# Altium schematic sheet Hovedkort.SchDoc
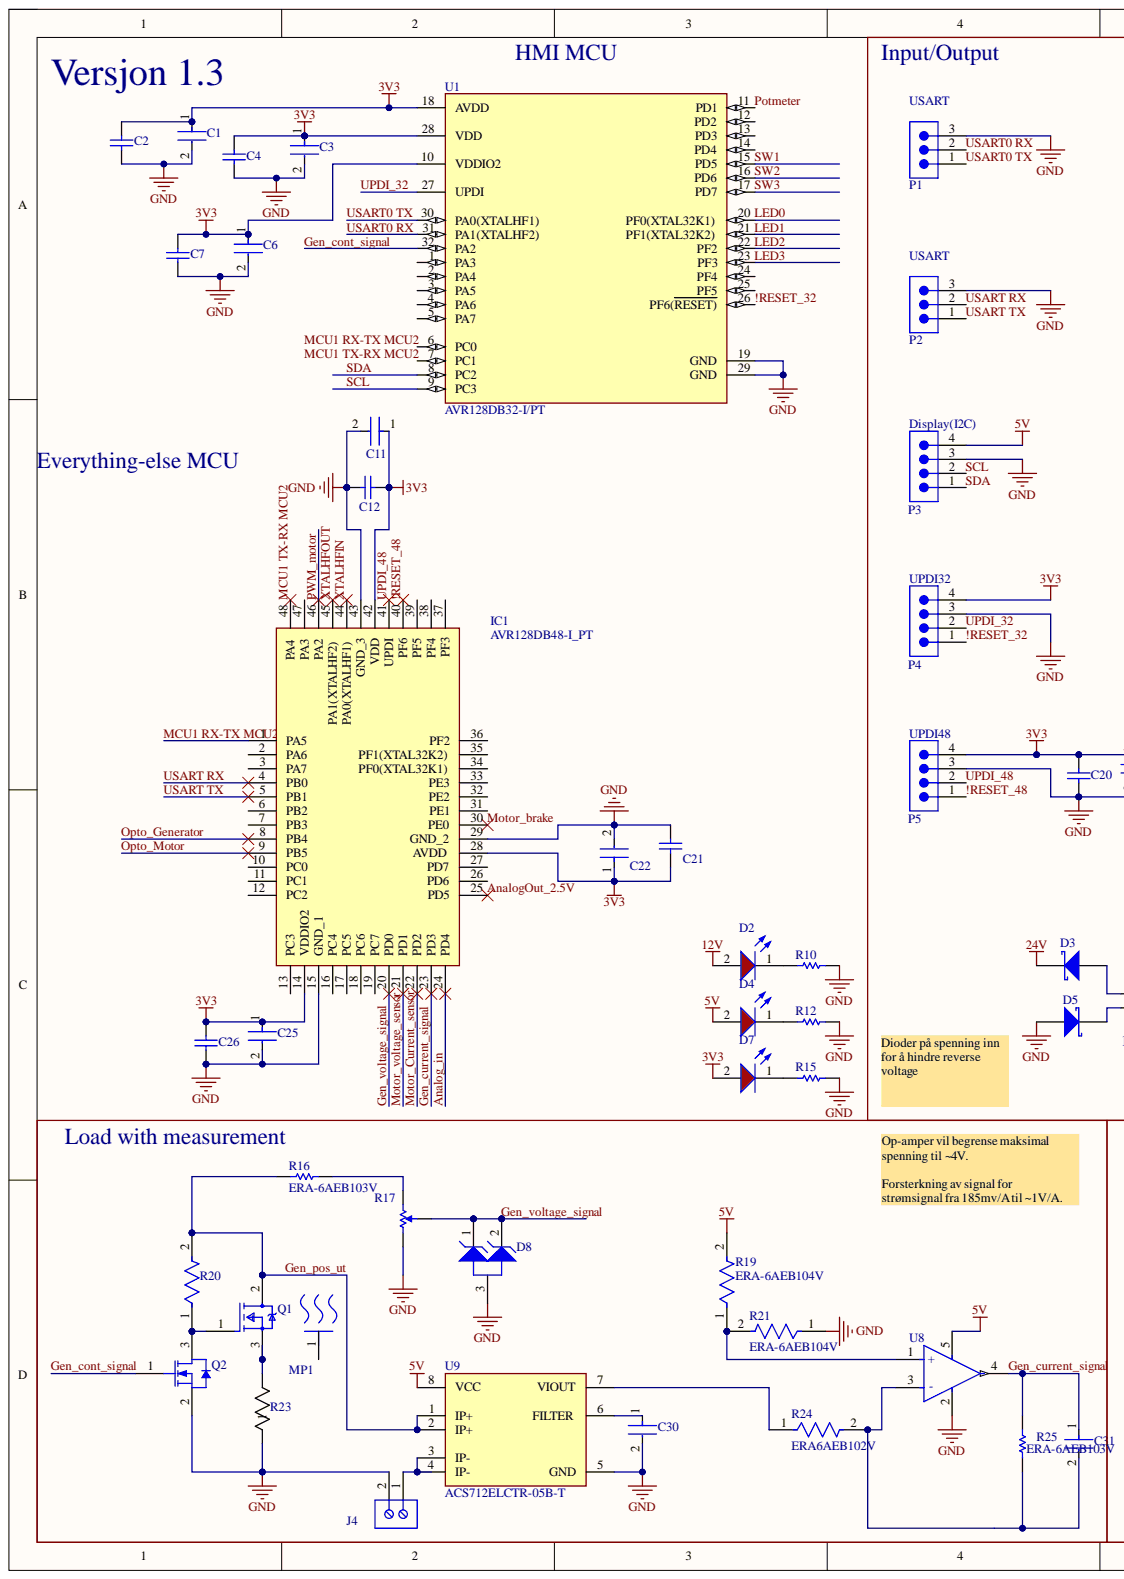
[diagram p0: Hovedkort.SchDoc, left]
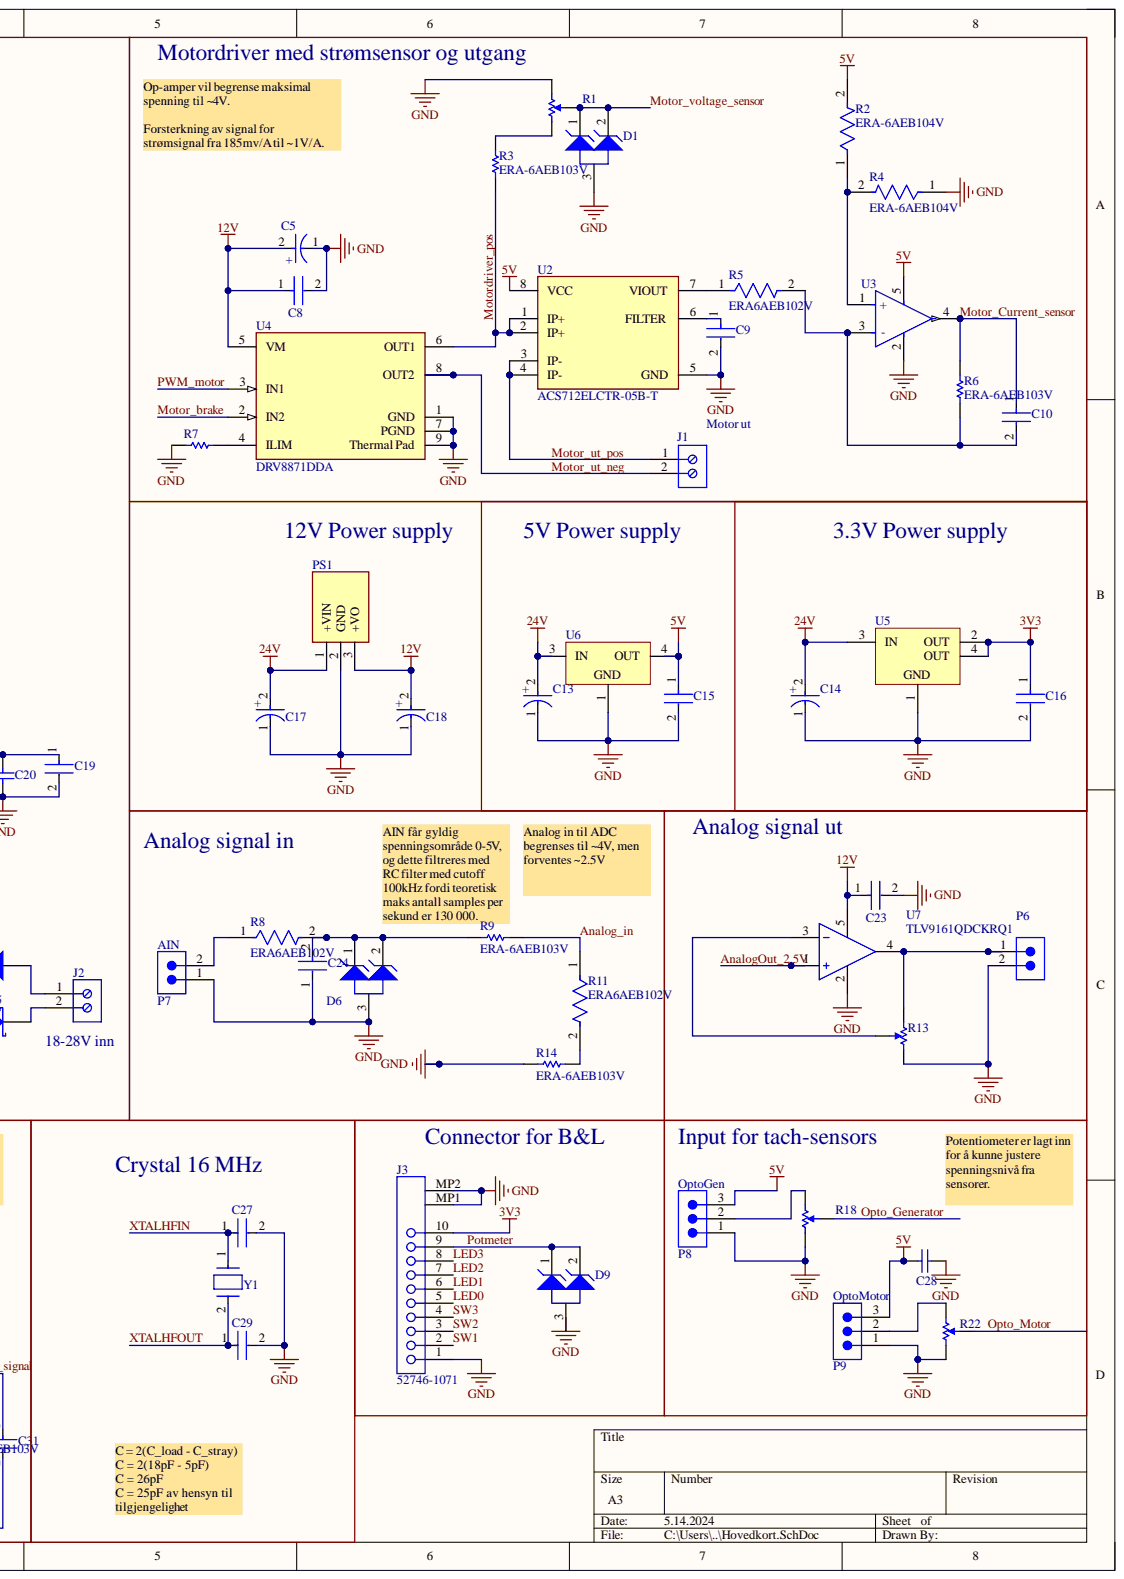
[diagram p1: Hovedkort.SchDoc, right]

## Parts
C1  08055C104KAT2A  [FP-0805-L_2_01_0_2-W_1_25-IPC_C]  (value not drawn)
C2  C0805C103K5RACTU  [CAPC2013X88X50LL20T25]  (value not drawn)
C3  08055C104KAT2A  [FP-0805-L_2_01_0_2-W_1_25-IPC_C]  (value not drawn)
C4  C0805C103K5RACTU  [CAPC2013X88X50LL20T25]  (value not drawn)
C5  EEEFK1V220R  [FP-FKC-MFG]  (value not drawn)
C6  08055C104KAT2A  [FP-0805-L_2_01_0_2-W_1_25-IPC_C]  (value not drawn)
C7  C0805C103K5RACTU  [CAPC2013X88X50LL20T25]  (value not drawn)
C8  08055C104KAT2A  [FP-0805-L_2_01_0_2-W_1_25-IPC_C]  (value not drawn)
C9  08055C104KAT2A  [FP-0805-L_2_01_0_2-W_1_25-IPC_C]  (value not drawn)
C10  C0805C102J1GACTU  [FP-C0805C-DN-MFG]  (value not drawn)
C11  08055C104KAT2A  [FP-0805-L_2_01_0_2-W_1_25-IPC_C]  (value not drawn)
C12  C0805C103K5RACTU  [CAPC2013X88X50LL20T25]  (value not drawn)
C13  EEE-FK1H100UR  [FP-FKC-MFG]  (value not drawn)
C14  EEE-FK1H100UR  [FP-FKC-MFG]  (value not drawn)
C15  C0805C106K8PACTU  [FP-C0805C-DG-MFG]  (value not drawn)
C16  C0805C106K8PACTU  [FP-C0805C-DG-MFG]  (value not drawn)
C17  EEEFK1V220R  [FP-FKC-MFG]  (value not drawn)
C18  EEEFK1V220R  [FP-FKC-MFG]  (value not drawn)
C19  08055C104KAT2A  [FP-0805-L_2_01_0_2-W_1_25-IPC_C]  (value not drawn)
C20  C0805C103K5RACTU  [CAPC2013X88X50LL20T25]  (value not drawn)
C21  C0805C103K5RACTU  [CAPC2013X88X50LL20T25]  (value not drawn)
C22  08055C104KAT2A  [FP-0805-L_2_01_0_2-W_1_25-IPC_C]  (value not drawn)
C23  08055C104KAT2A  [FP-0805-L_2_01_0_2-W_1_25-IPC_C]  (value not drawn)
C24  GRM2165C2A162JA01D  [FP-GRM216-0_1-IPC_A]  (value not drawn)
C25  08055C104KAT2A  [FP-0805-L_2_01_0_2-W_1_25-IPC_C]  (value not drawn)
C26  C0805C103K5RACTU  [CAPC2013X88X50LL20T25]  (value not drawn)
C27  C0805C103J3GACTU  [FP-C0805C-DN-MFG]  (value not drawn)
C28  C0805C103K5RACTU  [CAPC2013X88X50LL20T25]  (value not drawn)
C29  C0805C103J3GACTU  [FP-C0805C-DN-MFG]  (value not drawn)
C30  08055C104KAT2A  [FP-0805-L_2_01_0_2-W_1_25-IPC_C]  (value not drawn)
C31  C0805C102J1GACTU  [FP-C0805C-DN-MFG]  (value not drawn)
D1  MMBZ6V2ALT1G  [ONSC-SOT-23-3-318-08_V]  (value not drawn)
D2  150080SS75000  [WL-SMCW_0805_150080xx75000]  (value not drawn)
D3  STPS2H100A  [STM-SMA-2_V]  (value not drawn)
D4  Max Reverse Voltage (DC): 5V  [WL-SMCW_0805_150080xx75000]  (hidden comment: 150080SS75000)
D5  STPS2H100A  [STM-SMA-2_V]  (value not drawn)
D6  ESD7L5.0DT5G  [ONSC-SOT-723-3-631AA-01_V]  (value not drawn)
D7  150080SS75000  [WL-SMCW_0805_150080xx75000]  (value not drawn)
D8  MMBZ6V2ALT1G  [ONSC-SOT-23-3-318-08_V]  (value not drawn)
D9  SZMMBZ27VAWT1G  [ONSC-SC-70-3-419-04_V]  (value not drawn)
IC1  AVR128DB48-I_PT  [QFP50P900X900X120-48N]
J1  691103110002  [691103110002]  (value not drawn)
J2  691103110002  [691103110002]  (value not drawn)
J3  52746-1071  [MOLX-52746-1071]
J4  691103110002  [691103110002]  (value not drawn)
MP1  FA-T220-25E  [FP-FA-T220-25E-MFG]  (value not drawn)
P1  61300311121  [61300311121]  (value not drawn)
P2  61300311121  [61300311121]  (value not drawn)
P3  61300411121  [61300411121]  (value not drawn)
P4  61300411121  [61300411121]  (value not drawn)
P5  61300411121  [61300411121]  (value not drawn)
P6  61300211121  [61300211121]  (value not drawn)
P7  61300211121  [61300211121]  (value not drawn)
P8  61300311121  [61300311121]  (value not drawn)
P9  61300311121  [61300311121]  (value not drawn)
PS1  P7812-2000R-S  [P78032000RS]  (value not drawn)
Q1  IRFZ44VPBF  [FP-PG-TO220-3-MFG]  (value not drawn)
Q2  BSH103,215  [NXP-SOT23_N]  (value not drawn)
R1  TC33X-2-103E  [BOUR-TC33X-2_V]  (value not drawn)
R2  ERA-6AEB104V  [FP-ERA6A-IPC_B]
R3  ERA-6AEB103V  [RESC2013X60X40LL20T25_A]
R4  ERA-6AEB104V  [FP-ERA6A-IPC_B]
R5  ERA6AEB102V  [FP-ERA6A-IPC_C]
R6  ERA-6AEB103V  [RESC2013X60X40LL20T25_A]
R7  ERJ-6GEYJ303V  [RESC2013X70X40NL20T20]  (value not drawn)
R8  ERA6AEB102V  [FP-ERA6A-IPC_C]
R9  ERA-6AEB103V  [RESC2013X60X40LL20T25_A]
R10  ERJ-6GEYJ511V  [RESC2013X70X40ML20T20]  (value not drawn)
R11  ERA6AEB102V  [FP-ERA6A-IPC_C]
R12  ERA6AEB1400V  [RESC2013X60X40NL20T25]  (value not drawn)
R13  TC33X-2-103E  [BOUR-TC33X-2_V]  (value not drawn)
R14  ERA-6AEB103V  [RESC2013X60X40LL20T25_A]
R15  ERJ6ENF56R0V  [RESC2013X70X40NL20T20]  (value not drawn)
R16  ERA-6AEB103V  [RESC2013X60X40LL20T25_A]
R17  TC33X-2-103E  [BOUR-TC33X-2_V]  (value not drawn)
R18  TC33X-2-103E  [BOUR-TC33X-2_V]  (value not drawn)
R19  ERA-6AEB104V  [FP-ERA6A-IPC_B]
R20  CRCW080510K0FKEA  [FP-CRCW0805-e3-IPC_A]  (value not drawn)
R21  ERA-6AEB104V  [FP-ERA6A-IPC_B]
R22  TC33X-2-103E  [BOUR-TC33X-2_V]  (value not drawn)
R23  AC05000001008JAC00  [RES_AC05_VIS]  (value not drawn)
R24  ERA6AEB102V  [FP-ERA6A-IPC_C]
R25  ERA-6AEB103V  [RESC2013X60X40LL20T25_A]
U1  AVR128DB32-I/PT  [FP-C04-074-PT-MFG]
U2  ACS712ELCTR-05B-T  Operating Supply Voltage: 5V  [FP-LC-8-IPC_A]
U3  LM321LVIDCKR  [FP-DCK0005A-IPC_B]  (value not drawn)
U4  DRV8871DDA  [FP-DDA0008E-IPC_B]
U5  LD1086D2M33TR  [D2PAK_A_L]  (value not drawn)
U6  LD1086D2T50TR  [TO-263_N]  (value not drawn)
U7  TLV9161QDCKRQ1  [FP-DCK0005A-MFG]
U8  LM321LVIDCKR  [FP-DCK0005A-IPC_B]  (value not drawn)
U9  ACS712ELCTR-05B-T  Operating Supply Voltage: 5V  [FP-LC-8-IPC_A]
Y1  ABM3-16.000MHZ-B2-T  [FP-ABM3-MFG]  (value not drawn)

## Nets
3V3: C1.1 C2.2 C3.1 C4.2 C6.1 C7.2 C11.1 C12.2 C16.1 C19.1 C20.2 C21.2 C22.1 C25.1 C26.2 D7.2 IC1.14(VDDIO2) IC1.28(AVDD) IC1.42(VDD) J3.10 P4.4 P5.4 U1.10(VDDIO2) U1.18(AVDD) U1.28(VDD) U5.2(OUT) U5.4(OUT)
5V: C15.1 C28.2 D4.2 P3.4 P8.3 P9.3 R2.2 R19.2 U2.8(VCC) U3.5 U6.4 U8.5 U9.8(VCC)
12V: C5.2 C8.1 C18.2 C23.1 D2.2 PS1.3 U4.5(VM) U7.5
24V: C13.2 C14.2 C17.2 D3.2 PS1.1 U5.3(IN) U6.3
GND: C1.2 C2.1 C3.2 C4.1 C5.1 C6.2 C7.1 C8.2 C9.2 C11.2 C12.1 C13.1 C14.1 C15.2 C16.2 C17.1 C18.1 C19.2 C20.1 C21.1 C22.2 C23.2 C24.1 C25.2 C26.1 C27.2 C28.1 C29.2 C30.2 D1.3 D5.1 D6.3 D8.3 IC1.15(GND_1) IC1.29(GND_2) IC1.43(GND_3) J3.1 J3.MP1 J3.MP2 J4.2 P1.3 P2.3 P3.3 P4.3 P5.3 P6.2 P7.1 P8.1 P9.1 PS1.2 Q2.2 R1.3 R4.1 R7.2 R10.2 R12.2 R13.3 R14.1 R15.2 R17.3 R18.1 R21.1 R22.1 R23.1 U1.19(GND) U1.29(GND) U2.5(GND) U3.2 U4.1(GND) U4.7(PGND) U4.9(Thermal_Pad) U5.1 U6.1 U7.2 U8.2 U9.5(GND)
!RESET_32: P4.1 U1.26(PF6(RESET))
!RESET_48: IC1.40(PF6) P5.1
Analog_in: IC1.24(PD4) R9.1 R11.1
AnalogOut_2.5V: IC1.25(PD5) U7.1
Gen_cont_signal: Q2.1 U1.32(PA2)
Gen_current_signal: C31.1 IC1.23(PD3) R25.2 U8.4
Gen_pos_ut: Q1.2 R16.2 R20.2 U9.1(IP+) U9.2(IP+)
Gen_voltage_signal: D8.1 D8.2 IC1.20(PD0) R17.2
LED0: J3.5 U1.20(PF0(XTAL32K1))
LED1: J3.6 U1.21(PF1(XTAL32K2))
LED2: J3.7 U1.22(PF2)
LED3: J3.8 U1.23(PF3)
MCU1 RX-TX MCU2: IC1.1(PA5)
MCU1 TX-RX MCU2: IC1.48(PA4)
Motor_brake: IC1.30(PE0) U4.2(IN2)
Motor_Current_sensor: C10.1 IC1.22(PD2) R6.2 U3.4
Motor_ut_neg: J1.2 U4.8(OUT2)
Motor_ut_pos: J1.1 U2.3(IP-) U2.4(IP-)
Motor_voltage_sensor: D1.1 D1.2 IC1.21(PD1) R1.2
Motordriver_pos: R3.1 U2.1(IP+) U2.2(IP+) U4.6(OUT1)
Opto_Generator: IC1.8(PB4) R18.2
Opto_Motor: IC1.9(PB5) R22.2
Potmeter: D9.1 D9.2 J3.9 U1.11(PD1)
PWM_motor: IC1.46(PA2) U4.3(IN1)
SCL: P3.2 U1.9(PC3)
SDA: P3.1 U1.8(PC2)
SW1: J3.2 U1.15(PD5)
SW2: J3.3 U1.16(PD6)
SW3: J3.4 U1.17(PD7)
UPDI_32: P4.2 U1.27(UPDI)
UPDI_48: IC1.41(UPDI) P5.2
USART0 RX: P1.2 U1.31(PA1(XTALHF2))
USART0 TX: P1.1 U1.30(PA0(XTALHF1))
USART RX: IC1.4(PB0) P2.2
USART TX: IC1.5(PB1) P2.1
XTALHFIN: C27.1 IC1.44(PA0(XTALHF1)) Y1.1
XTALHFOUT: C29.1 IC1.45(PA1(XTALHF2)) Y1.2
NetC9_1: C9.1 U2.6(FILTER)
NetC10_2: C10.2 R5.2 R6.1 U3.3(-)
NetC24_2: C24.2 D6.1 D6.2 R8.2 R9.2
NetC30_1: C30.1 U9.6(FILTER)
NetC31_2: C31.2 R24.2 R25.1 U8.3(-)
NetD2_1: D2.1 R10.1
NetD3_1: D3.1 J2.1
NetD4_1: D4.1 R12.1
NetD5_2: D5.2 J2.2
NetD7_1: D7.1 R15.1
NetJ4_1: J4.1 U9.3(IP-) U9.4(IP-)
NetP6_1: P6.1 R13.1 U7.4
NetP7_2: P7.2 R8.1
NetP8_2: P8.2 R18.3
NetP9_2: P9.2 R22.3
NetQ1_1: Q1.1 Q2.3 R20.1
NetQ1_3: Q1.3 R23.2
NetR1_1: R1.1 R3.2
NetR2_1: R2.1 R4.2 U3.1(+)
NetR5_1: R5.1 U2.7(VIOUT)
NetR7_1: R7.1 U4.4(ILIM)
NetR11_2: R11.2 R14.2
NetR13_2: R13.2 U7.3
NetR16_1: R16.1 R17.1
NetR19_1: R19.1 R21.2 U8.1(+)
NetR24_1: R24.1 U9.7(VIOUT)

Not connected: D9 3; IC1 2(PA6) 3(PA7) 6(PB2) 7(PB3) 10(PC0) 11(PC1) 12(PC2) 13(PC3) 16(PC4) 17(PC5) 18(PC6) 19(PC7) 26(PD6) 27(PD7) 31(PE1) 32(PE2) 33(PE3) 34(PF0(XTAL32K1)) 35(PF1(XTAL32K2)) 36(PF2) 37(PF3) 38(PF4) 39(PF5) 47(PA3); MP1 1; U1 1(PA3) 2(PA4) 3(PA5) 4(PA6) 5(PA7) 6(PC0) 7(PC1) 12(PD2) 13(PD3) 14(PD4) 24(PF4) 25(PF5)

## Library data of the parts
C1 C3 C6 C8 C9 C11 C19 C22 C23 C25 C30 = CMP-2000-05016-2: General Purpose Ceramic Capacitor, 0805, 100nF, 10%, X7R, 0.15, 50V; Dissipation Factor: 2.5%; Insulation Resistance: 100GR; Capacitance: 100nF; Case Code (Imperial): 0805; Case Code (Metric): 2012; Case/Package: 0805; Component Kind: Standard; Depth: 1.25mm; Dielectric: X7R; Dielectric Material: Ceramic; Height: 0.5mm; Lead Free: Lead Free; Length: 2mm; Library Name: Altium Content Vault; Max Operating Temperature: 125°C; Min Operating Temperature: -55°C; Mount: Surface Mount; Number of Pins: 2; Package Quantity: 4000; Packaging: Cut Tape; Radiation Hardening: No; REACH SVHC: No SVHC; RoHS Compliant: Yes; Series: MLCC X7R; Termination: Standard; Thickness: 0.037inch; Tolerance: 10%; Voltage: 50V; Voltage Rating: 50V; Voltage Rating (DC): 50V; Weight: 0.001411oz; Width: 1.2mm
C2 C4 C7 C12 C20 C21 C26 C28 = CMP-2007-00266-1: Manufacturer: Kemet; Component Kind: Standard; Library Name: Altium Content Vault; Part Number: C0805C103K5RACTU
C5 C17 C18 = CMP-05427-000063-1: CAP ALUM 22UF 20% 35V SMD; Dielectric Material: Aluminium; ESR (Equivalent Series Resistance): 700mR; Leakage Current: 3uA; Life (Hours): 2000Hour; Ripple Current: 160mA; Capacitance: 22uF; Case/Package: Radial; Component Kind: Standard; Construction: Cylindrical; Contact Plating: Tin; Diameter: 5mm; Height: 5.8mm; Height - Seated (Max): 0.228inch; Impedance: 700mR; Lead Free: Lead Free; Length: 5.8mm; Library Name: Altium Content Vault; Material: Aluminium; Max Operating Temperature: 105°C; Min Operating Temperature: -55°C; Mount: Surface Mount; Number of Pins: 2; Package Quantity: 1; Packaging: Tape and Reel; Radiation Hardening: No; REACH SVHC: No SVHC; Ripple Current (AC): 160mA; RoHS Compliant: Yes; Series: FK; Termination: SMD/SMT; Tolerance: 20%; Voltage: 35V; Voltage Rating: 35V; Voltage Rating (DC): 35V; Width: 6.5mm
C10 C31 = CMP-2007-00233-2: CAP CER 1000PF 100V C0G/NP0 0805; Manufacturer: KEMET; Capacitance: 1,000 pF; Material: Ceramic; Temperature Characteristic: C0G; Temperature Coefficient: 30ppm/°C; Tolerance: 0.05; Value: 1,000 pF; Automotive: No; Category: Cap; Component Kind: Standard; ComponentLink2Description: Datasheet; ComponentLink2URL: https://content.kemet.com/datasheets/KEM_C1003_C0G_SMD.pdf; Device Class L1: Passive Components; Device Class L2: Capacitors; Device Class L3: Ceramic Capacitors; Digikey Description: CAP CER 1000PF 100V C0G/NP0 0805; Height: 0.88mm; Ipc Land Pattern Name: CAPC200125X78; Lead Free: Yes; Library Name: Altium Content Vault; Manufacturer Part Number: C0805C102J1GACTU; Mouser Description: Multilayer Ceramic Capacitors MLCC - SMD/SMT 100volts 1000pF C0G 5%; Octopart: https://octopart.com/c0805c102j1gactu-kemet-105157; Package: C0805C-DN; RoHS: TRUE; Temperature: -55°C to +125°C; Temperature Range High: +125°C; Temperature Range Low: -55°C; Voltage Rating: 100 V
C13 C14 = CMP-05427-000030-1: CAP ALUM 10UF 20% 50V SMD; Dielectric Material: Aluminium; ESR (Equivalent Series Resistance): 1.52R; Leakage Current: 3uA; Life (Hours): 2000Hour; Ripple Current: 85mA; Capacitance: 10uF; Case/Package: Radial; Component Kind: Standard; Construction: Cylindrical; Contact Plating: Tin; Diameter: 5mm; Height: 5.8mm; Height - Seated (Max): 0.228inch; Impedance: 1.52R; Lead Free: Lead Free; Length: 5.8mm; Library Name: Altium Content Vault; Material: Aluminium; Max Operating Temperature: 105°C; Min Operating Temperature: -55°C; Mount: Surface Mount; Number of Pins: 2; Package Quantity: 1000; Packaging: Tape and Reel; Radiation Hardening: No; REACH SVHC: No SVHC; Ripple Current (AC): 85mA; RoHS Compliant: Yes; Series: FK; Termination: SMD/SMT; Tolerance: 20%; Voltage: 50V; Voltage Rating: 50V; Voltage Rating (DC): 50V; Width: 6.5mm
C15 C16 = CMP-2007-03243-2: CAP CER 10UF 10V X5R 0805; 3DS Part Number: 220-00001; Manufacturer: Kemet; Material: Ceramic; Temperature Coefficient: 15%; Tolerance: 10%; Automotive: No; Capacitance: 10uF; Category: Cap; Component Kind: Standard; ComponentLink2Description: Datasheet; ComponentLink2URL: https://content.kemet.com/datasheets/KEM_C1006_X5R_SMD.pdf; Device Class L1: Passive Components; Device Class L2: Capacitors; Device Class L3: Ceramic Capacitors; Digikey Description: CAP CER 10UF 10V X5R 0805; Height: 1.4mm; Ipc Land Pattern Name: CAPC200125X125; Lead Free: Yes; Library Name: Altium Content Vault; Manufacturer Part Number: C0805C106K8PACTU; Mouser Description: CAP CER 10UF 10V X5R 0805; Octopart: https://octopart.com/c0805c106k8pactu-kemet-98697; Package: C0805C-DG; RoHS: TRUE; Temperature: -55°C to +85°C; Temperature Characteristic: X5R; Temperature Range High: +85°C; Temperature Range Low: -55°C; Value: 10uF; Voltage: 10V; Voltage Rating: 10V
C24 = CMP-2007-00914-2: Chip Multilayer Ceramic Capacitors for General Purpose, 0805, 1600pF, C0G, 30ppm/°C, 5%, 100V; Capacitance: 1.6nF; Case Code (Imperial): 0805; Case Code (Metric): 2012; Case/Package: 0805; Component Kind: Standard; Construction: Flat; Depth: 1.25mm; Dielectric: C0G; Height: 0.6mm; Length: 2mm; Library Name: Altium Content Vault; Max Operating Temperature: 125°C; Min Operating Temperature: -55°C; Mount: Surface Mount; Package Quantity: 4000; Packaging: Tape and Reel; Radiation Hardening: No; RoHS Compliant: Yes; Series: GRM; Termination: SMD/SMT; Thickness: 0.028inch; Tolerance: 5%; Voltage Rating: 100V; Voltage Rating (DC): 100V; Weight: 0.000194oz; Width: 0.049inch
C27 C29 = CMP-2007-00493-2: CAP CER 10000PF 25V 5% NP0 0805; Manufacturer: KEMET; Capacitance: 10,000 pF; Material: Ceramic; Temperature Characteristic: C0G; Temperature Coefficient: 30ppm/°C; Tolerance: 0.05; Value: 10,000 pF; Automotive: No; Category: Cap; Component Kind: Standard; ComponentLink2Description: Datasheet; ComponentLink2URL: https://content.kemet.com/datasheets/KEM_C1003_C0G_SMD.pdf; Device Class L1: Passive Components; Device Class L2: Capacitors; Device Class L3: Ceramic Capacitors; Digikey Description: CAP CER 10000PF 25V 5% NP0 0805; Height: 0.88mm; Ipc Land Pattern Name: CAPC200125X78; Lead Free: Yes; Library Name: Altium Content Vault; Manufacturer Part Number: C0805C103J3GACTU; Mouser Description: Multilayer Ceramic Capacitors MLCC - SMD/SMT 25volts 10000pF 5% C0G; Octopart: https://octopart.com/c0805c103j3gactu-kemet-12563663; Package: C0805C-DN; RoHS: TRUE; Temperature: -55°C to +125°C; Temperature Range High: +125°C; Temperature Range Low: -55°C; Voltage Rating: 25 V
D1 D8 = CMP-1060-01227-1: 24 W Peak Power Zener Transient Voltage Suppressor, Dual Common Anode Zeners for ESD Protection, 3-Pin SOT-23, Pb-Free, Tape and Reel; Manufacturer: On Semiconductor; VZ Typ (V): 6.2; Component Kind: Standard; ComponentLink1Description: Manufacturer URL; ComponentLink1URL: http://www.onsemi.com/; ComponentLink2Description: Datasheet; ComponentLink2URL: http://www.onsemi.cn/pub_link/Collateral/MMBZ5V6ALT1-D.PDF; ComponentLink3Description: Package Specification; ComponentLink3URL: http://www.onsemi.cn/pub_link/Collateral/318-08.PDF; DatasheetVersion: Rev. 13; Library Name: Altium Content Vault; Mounting Technology: Surface Mount; PackageDescription: 3-Pin Small Outline Transistor, Body 2.92 x 1.3 mm, Pitch 0.95 mm; PackageReference: SOT-23-3-318-08; PackageVersion: Rev. AP, 11/2009; Packing: Tape and Reel; PartNumber: MMBZ6V2ALT1G; RoHS: Pb-Free
D2 D7 = CMP-1426-00010-3: NexarPartId: 29717782; Color: Red; Dominant Wavelength: 630nm; Forward Current: 100mA; Forward Voltage: 1.9V; Lens Style: Clear, Rectangular; Luminous Intensity: 60mcd; Max Junction Temperature (Tj): 95°C; Max Reverse Voltage (DC): 5V; Peak Wavelength: 645nm; Power Dissipation: 72mW; Test Current: 20mA; Viewing Angle: 140°; Case Code (Imperial): 0805; Case Code (Metric): 2012; Case/Package: 0805; Component Kind: Standard; Height: 700µm; Length: 2mm; Library Name: Altium Content Vault; Max Operating Temperature: 85°C; Min Operating Temperature: -40°C; Mount: Surface Mount; Packaging: Tape and Reel; REACH SVHC: Unknown; Reverse Voltage: 5V; RoHS: Compliant; Wavelength: 630nm; Width: 1.25mm
D3 D5 = CMP-2000-07175-1: Power Schottky Rectifier, 2 A, 100 V, 2-Pin SMD (SMA), RoHS, Tape and Reel; Manufacturer: STMicroelectronics; Current - Average Rectified (Io): 2A; Current - Reverse Leakage @ Vr: 1µA@100V; Online Catalog: SchottkyDiode; Other Names: 497-3758-6; Other Related Documents: STPS2H100ViewAllSpecifications; Speed: FastRecovery=200mA(Io); Voltage - DC Reverse (Vr) (Max): 100V; Voltage - Forward (Vf) (Max) @ If: 790mV@2A; Capacitance @ Vr, F: -; Category: DiscreteSemiconductorProducts; Component Kind: Standard; Datasheet URL: http://www.st.com/content/ccc/resource/technical/document/datasheet/0a/8b/df/ef/15/72/4f/38/CD00001548.pdf/files/CD00001548.pdf/jcr:content/translations/en.CD00001548.pdf; Datasheet Version: 06/2013; Diode Type: Schottky; Family: Diodes,Rectifiers-Single; Library Name: Altium Content Vault; Manufacturer URL: http://www.st.com/; Mounting Technology: SurfaceMount; Mounting Type: SurfaceMount; Operating Temperature - Junction: 175°C(Max); Package / Case: DO-214AC,SMA; Package Description: 2-Pin Surface Mount Device, Body 4.275 x 2.575 mm; Package Reference: SMA; Package URL: http://www.st.com/st-web-ui/static/active/en/resource/technical/document/datasheet/CD00283518.pdf; Packaging: Digi-Reel®; Reverse Recovery Time (trr): -; Series: -; Standard Package: 1; Supplier Device Package: SMA(DO-214AC)
D4 = CMP-1426-00010-3: NexarPartId: 29717782; Color: Red; Dominant Wavelength: 630nm; Forward Current: 100mA; Forward Voltage: 1.9V; Lens Style: Clear, Rectangular; Luminous Intensity: 60mcd; Max Junction Temperature (Tj): 95°C; Peak Wavelength: 645nm; Power Dissipation: 72mW; Test Current: 20mA; Viewing Angle: 140°; Case Code (Imperial): 0805; Case Code (Metric): 2012; Case/Package: 0805; Component Kind: Standard; Height: 700µm; Length: 2mm; Library Name: Altium Content Vault; Max Operating Temperature: 85°C; Min Operating Temperature: -40°C; Mount: Surface Mount; Packaging: Tape and Reel; REACH SVHC: Unknown; Reverse Voltage: 5V; RoHS: Compliant; Wavelength: 630nm; Width: 1.25mm
D6 = CMP-1063-00213-1: Transient Voltage Suppressor, ESD Protection Diode with Ultra-Low Capacitance, 3-Pin SOT-723, Pb-Free, Tape and Reel; Manufacturer: On Semiconductor; Direction: Unidirectional; IR Max (uA): 1; Number of Lines: 2; V(BR) Min (V): 5.4; VRWM Max (V): 5; Component Kind: Standard; ComponentLink1Description: Manufacturer URL; ComponentLink1URL: http://www.onsemi.com/; ComponentLink2Description: Datasheet; ComponentLink2URL: http://www.onsemi.cn/pub_link/Collateral/ESD7L-D.PDF; ComponentLink3Description: Package Specification; ComponentLink3URL: http://www.onsemi.com/pub_link/Collateral/631AA.PDF; DatasheetVersion: Rev. 6; Library Name: Altium Content Vault; Mounting Technology: Surface Mount; PackageDescription: 3-Pin Small Outline Transistor, Body 1.2 x 0.8 mm, Pitch 0.4 mm; PackageReference: SOT-723-3-631AA-01; PackageVersion: Rev. D, 08/2009; Packing: Tape and Reel; PartNumber: ESD7L5.0DT5G; RoHS: Pb-Free
D9 = CMP-1061-00152-1: 40 W Peak Power Zener Transient Voltage Suppressor, Dual Common Anode Zeners for ESD Protection, 3-Pin SC70, Pb-Free, Tape and Reel; Manufacturer: On Semiconductor; Direction: Unidirectional; IR Max (uA): 0.05; PPK Max (W): 40; V(BR) Typ (V): 27; Component Kind: Standard; ComponentLink1Description: Manufacturer URL; ComponentLink1URL: http://www.onsemi.com/; ComponentLink2Description: Datasheet; ComponentLink2URL: http://www.onsemi.com/pub_link/Collateral/MMBZ27VAW-D.PDF; ComponentLink3Description: Package Specification; ComponentLink3URL: http://www.onsemi.cn/pub_link/Collateral/419-04.PDF; DatasheetVersion: Rev. 4; Library Name: Altium Content Vault; Mounting Technology: Surface Mount; PackageDescription: 3-Pin Small Outline Transistor, Body 2 x 1.25 mm, Pitch 0.65 mm; PackageReference: SC-70-3-419-04; PackageVersion: Rev. N, 11/2008; Packing: Tape and Reel; PartNumber: SZMMBZ27VAWT1G; RoHS: Pb-Free
IC1 = AVR128DB48-I_PT: Integrated Circuit; Manufacturer_Name: Microchip; Manufacturer_Part_Number: AVR128DB48-I/PT; Arrow Part Number: AVR128DB48-I/PT; Arrow Price/Stock: https://www.arrow.com/en/products/avr128db48-ipt/microchip-technology?region=nac; Component Kind: Standard; Datasheet Link: https://ww1.microchip.com/downloads/en/DeviceDoc/AVR128DB28-32-48-64-DataSheet-DS40002247A.pdf; Height: 1.2mm; Library Name: AVR128DB48-iPTv3.IntLib; Mouser Part Number: 579-AVR128DB48-I/PT; Mouser Price/Stock: https://www.mouser.co.uk/ProductDetail/Microchip-Technology/AVR128DB48-I-PT?qs=vmHwEFxEFR8J6TEwJS0Zlw%3D%3D
J1 J2 J4 = CMP-1502-03254-2: NexarPartId: 18818286; Current: 10A; Number of Contacts: 2; Number of Levels: 1; Pitch: 3.5052mm; Plating: Steel; Stripping Length: -6mm; Voltage: 125V; Wire Gauge (Max): 26AWG; Wire Gauge (Min): 16AWG; Color: Blue; Component Kind: Standard; Contact Material: Brass, Copper, Nickel, Tin, Zinc; Contact Plating: Tin; Current Rating: 10A; Height: 8.5mm; Housing Material: Nylon; Lead Pitch: 3.5mm; Length: 7mm; Library Name: Altium Content Vault; Max Operating Temperature: 105°C; Min Operating Temperature: -40°C; Mount: Through Hole; Number of Pins: 2; Number of Positions Per Level: 2; Number of Rows: 1; Packaging: Box; REACH SVHC: Unknown; RoHS: Compliant; Termination: Screw; Voltage Rating: 125V; Width: 8mm; Wire/Cable Gauge: 26AWG
J3 = CMP-1241-00372-1: 0.5 mm Pitch Easy-On(TM) Type FPC Connector, 2.0 mm Mated Height, Right Angle, ZIF, SMT, Bottom Contact, 10 Circuits, -20 to 85 degC, ELV and RoHS Compliant, Tape and Reel; Manufacturer: Molex; Circuits (Loaded): 10; Current (Max per Contact): 0.5A; Durability (mating cycles max): 20; Entry Angle: 90degrees Angle; Mated Height: 2.00mm; Material - Metal: Phosphor Bronze; Material - Plating Mating: Gold; PCB Locator: No; PCB Retention: Yes; Pitch - Mating Interface: 0.50mm; Voltage (Max): 50V; Component Kind: Standard; ComponentLink1Description: Manufacturer URL; ComponentLink1URL: http://www.molex.com/molex/index.jsp; ComponentLink2Description: Datasheet; ComponentLink2URL: http://www.molex.com/webdocs/datasheets/pdf/en-us/0527461071_FFC_FPC_CONNECTORS.pdf; ComponentLink3Description: Package Specification; ComponentLink3URL: http://www.molex.com/pdm_docs/sd/527461071_sd.pdf; Contact Position: Bottom; Library Name: Altium Content Vault; Material - Plating Termination: Gold; Mounting Technology: Surface Mount; Number of Rows: 1; Orientation: Right Angle; PackageDescription: 10-Lead FPC Connector, Pitch 0.5 mm; PackageReference: 52746-1071; PackageVersion: Rev. H, 05/2009; Packing: Tape and Reel; PartNumber: 52746-1071; Polarized to PCB: Yes; RoHS: TRUE; Stackable: No
MP1 = CMP-33535-000029-1: HEATSINK TO-218,TO-220,TO-247; Finish: Anodized; Natural Thermal Resistance: 4.7°C/W; Shape: Fins; Thermal Resistance @ Forced Air Flow (100 LFM): 3°C/W; Case/Package: TO-220; Color: Black; Component Kind: Standard; Height: 25.8mm; Height Off Base: 0.984inch; Length: 41.6mm; Library Name: Altium Content Vault; Material: Aluminium; Mount: Bolt; Package Cooled: TO-220; Package Quantity: 10; Packaging: Bulk; RoHS Compliant: Yes; Series: F; Type: Passive; Weight: 18g; Width: 25mm
P1 P2 P8 P9 = CMP-1502-01062-2: NexarPartId: 32855521; Connector Type: Male Pin; Contact Resistance: 20mΩ; Current Rating: 3A; Gender: Male; Number of Contacts: 3; Operating Supply Voltage: 250V; Pitch: 2.54mm; Color: Black; Component Kind: Standard; Contact Material: Copper; Contact Mating Length: 5.9944mm; Contact Plating: Gold; Height: 8.94mm; Lead Free: Lead Free; Lead Pitch: 2.54mm; Library Name: Altium Content Vault; Max Operating Temperature: 105°C; Min Operating Temperature: -40°C; Mount: Through Hole; Number of Pins: 3; Number of Positions: 3; Number of Rows: 1; Orientation: Vertical; Packaging: Bulk; REACH SVHC: Unknown; RoHS: Compliant; Termination: Solder; Voltage Rating: 250V
P3-P5 = CMP-1502-01064-2: NexarPartId: 32855522; Connector Type: Male Pin; Contact Resistance: 20mΩ; Current Rating: 3A; Gender: Male; Number of Contacts: 4; Operating Supply Voltage: 250V; Pitch: 2.54mm; Color: Black; Component Kind: Standard; Contact Material: Copper; Contact Mating Length: 5.9944mm; Contact Plating: Gold; Height: 8.89mm; Lead Free: Lead Free; Lead Pitch: 2.54mm; Library Name: Altium Content Vault; Max Operating Temperature: 105°C; Min Operating Temperature: -40°C; Mount: Through Hole; Number of Pins: 4; Number of Positions: 4; Number of Rows: 1; Orientation: Vertical; Packaging: Bulk; REACH SVHC: Unknown; RoHS: Compliant; Termination: Solder; Voltage Rating: 250V
P6 P7 = CMP-1712-00002-5: NexarPartId: 20081794; Connector Type: Male Pin; Contact Resistance: 20mΩ; Current Rating: 3A; Gender: Male; Number of Contacts: 2; Operating Supply Voltage: 250V; Pitch: 2.54mm; Color: Black; Component Kind: Standard; Contact Gender: Male; Contact Material: Copper; Contact Mating Length: 5.9944mm; Contact Plating: Gold; Height: 8.94mm; Housing Material: PBT; Lead Free: Lead Free; Lead Pitch: 2.54mm; Library Name: Altium Content Vault; Max Operating Temperature: 125°C; Min Operating Temperature: -40°C; Mount: Through Hole; Number of Pins: 2; Number of Positions: 2; Number of Rows: 1; Orientation: Vertical; Packaging: Bulk; REACH SVHC: No SVHC; RoHS: Compliant; Termination: Through Hole; Voltage Rating: 250V
PS1 = P7803-2000R-S: Power Supply; Manufacturer_Name: CUI Inc.; Component Kind: Standard; Datasheet Link: https://www.cui.com/product/resource/p78-2000r-s.pdf; Height: 9.5mm; Library Name: P7803-2000R-S.IntLib; Manufacturer_Part_Number: P7812-2000R-S
Q1 = CMP-32901-001154-1: MOSFET N-CH 60V 55A TO220AB; Drain to Source Resistance: 16.5mR; Element Configuration: Single; Gate to Source Voltage (Vgs): 20V; Input Capacitance: 1.812nF; Max Power Dissipation: 115W; Polarization: N; Threshold Voltage: 4V; Turn-Off Delay Time: 40ns; Turn-On Delay Time: 13ns; Case/Package: TO-220; Component Kind: Standard; Continuous Drain Current (ID): 55A; Drain to Source Breakdown Voltage: 60V; Drain to Source Voltage (Vdss): 60V; Halogen Free: Halogen Free; Height: 0.65inch; Lead Free: Lead Free; Length: 0.42inch; Library Name: Altium Content Vault; Max Dual Supply Voltage: 60V; Max Operating Temperature: 175°C; Min Operating Temperature: -55°C; Mount: Through Hole; Number of Pins: 3; Packaging: Rail/Tube; Power Dissipation: 115W; Rds On Max: 16.5mR; Reach SVHC Compliant: Yes; RoHS Compliant: Yes; Series: HEXFET®; Width: 0.19inch
Q2 = CMP-1258-00149-1: N-Channel Enhancement Mode MOS Transistor, 30 V, 0.85 A, 3-Pin SOT23, Tape and Reel; Manufacturer: NXP Semiconductors; Channel Type: N; Ciss [typ] (pF): 83; Coss [typ] (pF): 27; ID [max] (A): 0.85; Packing: Tape & Reel; VDS [max] (V): 30; Code_JEDEC: TO-236AB; Component Kind: Standard; ComponentLink1Description: Manufacturer URL; ComponentLink1URL: http://www.nxp.com/; ComponentLink2Description: Datasheet; ComponentLink2URL: http://www.nxp.com/documents/data_sheet/BSH103.pdf; ComponentLink3Description: Package Specification; ComponentLink3URL: http://www.nxp.com/documents/outline_drawing/sot023_po.pdf; Library Name: Altium Content Vault; Mounting Technology: Surface Mount; PackageDescription: 3-Pin Small Outline Transistor Package, Body 2.9 x 1.3 mm, Pitch 0.95 mm; PackageReference: SOT23; PackageVersion: 06-03-16, 03/2006; PartNumber: BSH103,215
R1 R13 R17 R18 R22 = CMP-2100-03695-1: Trimming Potentiometer, 10000 Ohm, -40 to 100 degC, 3-Pin SMD, RoHS, Tape and Reel; Manufacturer: Bourns; Max Power Dissipation: 100mW; Number of Turns: 1; Resistance: 10KOhm; Temperature Coefficient: 250ppm/degreesC; Tolerance: 25%; Voltage Rating (DC): 50V; Case/Package: SMD/SMT; Colour: Silver; Component Kind: Standard; Composition: Potentiometer; Contact Plating: Silver; Datasheet URL: http://www.bourns.com/docs/Product-Datasheets/TC33.pdf; Datasheet Version: 42583.0; Depth: 3.8mm; Height: 0.047inch; Lead Free: LeadFree; Length: 3mm; Library Name: Altium Content Vault; Manufacturer URL: http://www.bourns.com/; Max Operating Temperature: 100degC; Military Standard: Not; Min Operating Temperature: -40degC; Mount: SurfaceMount; Package Description: 3-Pin Surface Mount Device, Body 3.8 x 3.6 mm; Package Quantity: 2500; Package Reference: TC33X-2; Packaging: TapeandReel; Power Rating: 100mW; Radiation Hardening: No; REACH SVHC: NoSVHC; RoHSCompliant: true; Taper: Linear; Termination: FootPrint; Weight: 29.4mg; Width: 0.118inch
R2 R4 R19 R21 = CMP-2001-00583-2: RES 100K OHM 0.1% 1/8W 0805; Max Power Dissipation: 125mW; Resistance: 100kR; Temperature Coefficient: 25ppm/°C; Voltage Rating: 100V; Case Code (Imperial): 0805; Case Code (Metric): 2012; Case/Package: 0805; Component Kind: Standard; Construction: Rectangular; Contact Plating: Tin; Depth: 1.25mm; Features: Automotive AEC-Q200; Height: 0.5mm; Lead Free: Lead Free; Length: 2mm; Library Name: Altium Content Vault; Max Operating Temperature: 155°C; Military Standard: Not; Min Operating Temperature: -55°C; Mount: Surface Mount; Number of Pins: 2; Number of Terminations: 2; Package Quantity: 1; Packaging: Tape and Reel; Power Rating: 125mW; Radiation Hardening: No; REACH SVHC: No SVHC; Resistance Tolerance: 0.1%; Resistor Type: Thin Film; RoHS Compliant: Yes; Series: ERA-6A; Size Code: 0805; Technology: METAL FILM; Termination: Solder; Tolerance: 0.1%; Voltage Rating (DC): 100V; Width: 1.25mm
R3 R6 R9 R14 R16 R25 = CMP-2001-00582-2: Metal Film Chip Resistor, High Reliability Type, 0.125 W, +/- 0.1%, -55 to 155 degC, 0805 (2012 Metric), RoHS, Tape and Reel; Brand: Panasonic; Power Rating: 125mW(1/8W); Resistance: 10kOhms; Temperature Coefficient: 25PPM/C; Voltage Rating: 100V; Case Code - in: 0805; Case Code - mm: 2012; Component Kind: Standard; Datasheet URL: https://industrial.panasonic.com/cdbs/www-data/pdf/RDM0000/AOA0000C307.pdf; Datasheet Version: 02/2016; Factory Pack Quantity: 5000; Height: 0.5mm; Length: 2mm; Library Name: Altium Content Vault; Manufacturer: Panasonic; Manufacturer URL: http://www.panasonic.com/; Mounting Technology: SurfaceMount; Operating Temperature Range: -55Cto+155C; Package / Case: 0805(2012metric); Package Description: 2-Pin Surface Mount Device, Body 2 x 1.25 mm; Package Reference: 0805; Packaging: Reel; Product: ThinFilmResistorsSMD; Product Category: ThinFilmResistors-SMD; RoHS: Details; Series: ERA-6A; Termination Style: SMD/SMT; Tolerance: 0.1%; Type: MetalFilmChipResistors; Width: 1.25mm
R5 R8 R11 R24 = CMP-2001-00581-2: RES 1K OHM 0.1% 1/8W 0805; Max Power Dissipation: 125mW; Resistance: 1kR; Temperature Coefficient: 25ppm/°C; Voltage Rating: 100V; Case Code (Imperial): 0805; Case Code (Metric): 2012; Case/Package: 0805; Component Kind: Standard; Construction: Rectangular; Contact Plating: Tin; Depth: 1.25mm; Height: 0.5mm; Length: 2mm; Library Name: Altium Content Vault; Max Operating Temperature: 155°C; Military Standard: Not; Min Operating Temperature: -55°C; Mount: Surface Mount; Number of Pins: 2; Number of Terminations: 2; Package Quantity: 5000; Packaging: Tape and Reel; Power Rating: 125mW; Radiation Hardening: No; REACH SVHC: No SVHC; Resistance Tolerance: 0.1%; Resistor Type: Thin Film; RoHS Compliant: Yes; Series: ERA-6A; Technology: THIN FILM; Termination: SMD/SMT; Tolerance: 0.1%; Width: 1.25mm; Working Voltage: 100V
R7 = CMP-2001-00396-1: Manufacturer: Panasonic; Component Kind: Standard; Library Name: Altium Content Vault; Part Number: ERJ-6GEYJ303V
R10 = CMP-2001-00426-1: Manufacturer: Panasonic; Component Kind: Standard; Library Name: Altium Content Vault; Part Number: ERJ-6GEYJ511V
R12 = CMP-2001-00741-1: Manufacturer: Panasonic; Component Kind: Standard; Library Name: Altium Content Vault; Part Number: ERA6AEB1400V
R15 = CMP-2001-00560-1: Manufacturer: Panasonic; Component Kind: Standard; Library Name: Altium Content Vault; Part Number: ERJ6ENF56R0V
R20 = CMP-2001-04908-2: RES Thick Film, 10kΩ, 1%, 0.125W, 100ppm/°C, 0805; Power Rating: 125mW; Resistance: 10kR; Voltage Rating: 150V; Case Code (Imperial): 0805; Case Code (Metric): 2012; Case/Package: 0805; Component Kind: Standard; Composition: Thick Film; Contact Plating: Tin; Depth: 1.25mm; Height: 0.45mm; Lead Free: Lead Free; Length: 2mm; Library Name: Altium Content Vault; Max Operating Temperature: 155°C; Military Standard: Not; Min Operating Temperature: -55°C; Package Quantity: 5000; Packaging: Tape and Reel; Radiation Hardening: No; Temperature Coefficient: 100ppm/°C; Termination: SMD/SMT; Tolerance: 1%; Voltage Rating (DC): 150V; Weight: 0.000194oz; Width: 1.25mm
R23 = CMP-00030-41926998-1: AC05000001008JAC00 VISHAY AC05000001008JAC00 Through Hole Resistor, 1 ohm, Axial Leaded, 5 W, 5%, AC Series; Lead Diameter: 800µm; Max Power Dissipation: 5W; Resistance: 1Ω; Temperature Coefficient: 600ppm/°C; Voltage Rating: 2V; Case/Package: Conformal; Component Kind: Standard; Composition: Wirewound; Contact Plating: Tin; Diameter: 7.5mm; Features: Flame Retardant Coating; Lead Free: Lead Free; Length: 18mm; Library Name: Altium Content Vault; Max Operating Temperature: 275°C; Military Standard: Not; Min Operating Temperature: -40°C; Mount: Through Hole; NexarPartId: 41926998; Number of Pins: 2; Number of Terminations: 2; Packaging: Cut Tape (CT); Power Rating: 5W; Radiation Hardening: No; REACH SVHC: Unknown; RoHS: Compliant; Schedule B: 8533210080; Termination: Axial; Tolerance: 5%; Voltage Rating (DC): 2V; Width: 7.49mm
U1 = CMP-00252-108145377-1: AVR128DB32-I/PT 8-bit AVR MCU, 128 KB, 16384 Bytes, 24 MHz, 1.8 to 5.5V, TQFP-32, RoHS; Data Bus Width: 8b; Frequency: 24MHz; Interface: 2-Wire, I2C, Parallel, SMBus, SPI, USART; Max Junction Temperature (Tj): 145°C; Memory Size: 128kB; Memory Type: FLASH; Min Supply Voltage: 1.8V; Number of A/D Converters: 1; Number of ADC Channels: 13; Number of I2C Channels: 2; Number of I/Os: 26; Number of Timers/Counters: 5; Number of UART Channels: 3; RAM Size: 16kB; Ambient Temperature Range High: 85°C; Component Kind: Standard; Core Architecture: AVR; Height: 1.2mm; Library Name: Altium Content Vault; Lifecycle Status: Production; Max Operating Temperature: 85°C; Max Supply Voltage: 5.5V; Min Operating Temperature: -40°C; NexarPartId: 108145377; Number of SPI Channels: 2; Schedule B: 8542310000, 8542310000
U2 U9 = CMP-2000-04989-2: SENSOR CURRENT HALL 5A AC/DC; Accuracy: 1.5%; Bandwidth: 80kHz; Max Supply Current: 13mA; Max Supply Voltage: 5.5V; Min Supply Voltage: 4.5V; Number of Channels: 1; Output Current: 10mA; Polarity: Bidirectional; Response Time: 5us; Sensitivity: 185mV/A; Case/Package: SOIC; Component Kind: Standard; Current Sensing: 5A; Frequency: 80kHz; Height: 1.5mm; Lead Free: Lead Free; Length: 4.9mm; Library Name: Altium Content Vault; Linearity: 1.5%; Max Operating Temperature: 85°C; Min Operating Temperature: -40°C; Mount: Surface Mount; Number of Pins: 8; Package Quantity: 1; Packaging: Cut Tape; Quiescent Current: 10mA; REACH SVHC: No SVHC; RoHS Compliant: Yes; Series: -; Termination: SMD/SMT; Voltage: 5V; Width: 3.9mm
U3 U8 = CMP-04895-000147-1: IC OPAMP GP 1 CIRCUIT SC70-5; Component Kind: Standard; Library Name: Altium Content Vault; RoHS Compliant: Yes
U4 = CMP-04915-000036-1: IC MOTOR DRVR UNIPLR 8SO PWRPAD; Interface: PWM; Logic Function: AND GATE; Output Current: 3.6A; Component Kind: Standard; Lead Free: Lead Free; Library Name: Altium Content Vault; Max Operating Temperature: 125°C; Min Operating Temperature: -40°C; Mount: Surface Mount; Number of Pins: 8; Packaging: Rail/Tube; RoHS Compliant: Yes
U5 = CMP-0244-00505-1: 1.5A, Low Drop Positive Voltage Regulator, 3.3V, 4-Pin D2PAK/A, Tape and Reel; Component Kind: Standard; ComponentLink1Description: Manufacturer URL; ComponentLink1URL: http://www.st.com; ComponentLink2Description: Datasheet; ComponentLink2URL: http://www.st.com/internet/com/TECHNICAL_RESOURCES/TECHNICAL_LITERATURE/DATASHEET/CD00001884.pdf; DatasheetVersion: 11/07/2011; Library Name: Altium Content Vault; PackageDescription: 4-Pin Transistor Outline Package 2.54 mm Pitch, 15.4 mm Lead Span; PackageReference: D2PAK_A; PackageVersion: 2011
U6 = CMP-0244-00124-1: 1.5A, Low Drop Positive Voltage Regulator, 5.0V, 3-Pin D2PAK, Tape and Reel; Component Kind: Standard; ComponentLink1Description: Manufacturer URL; ComponentLink1URL: http://www.st.com; ComponentLink2Description: Datasheet; ComponentLink2URL: http://www.st.com/internet/com/TECHNICAL_RESOURCES/TECHNICAL_LITERATURE/DATASHEET/CD00001884.pdf; DatasheetVersion: 11/07/2011; Library Name: Altium Content Vault; PackageDescription: 3-Pin Transistor Outline Package 2.54 mm Pitch, 15.4 mm Lead Span (D2PAK); PackageReference: TO-263; PackageVersion: 2011
U7 = CMP-00370-136697917-1: TLV9161QDCKRQ1 Automotive, single, 16-V 11-MHz rail-to-rail input and output operational amplifier 5-SC70 -40 to 125; Manufacturer Lifecycle Status: ACTIVE; Input Bias Current: 10pA; Input Offset Voltage (Vos): 210µV; Max Dual Supply Voltage: 8V; Max Junction Temperature (Tj): 150°C; Max Supply Voltage: 16V; Min Dual Supply Voltage: 1.35V; Min Supply Voltage: 2.7V; Nominal Supply Current: 2.48mA; Number of Channels: 1; Output Current per Channel: 73mA; Response Time: 890ns; Voltage Gain: 136dB; Ambient Temperature Range High: 125°C; Component Kind: Standard; Height: 1.1mm; Length: 2mm; Library Name: Altium Content Vault; Lifecycle Status: Production; Max Operating Temperature: 125°C; Min Operating Temperature: -40°C; NexarPartId: 136697917; Number of Pins: 5; Thickness: 900µm; Width: 1.25mm
Y1 = CMP-27762-013555-1: Crystal 16MHz ±20ppm 18pF SMD-2 5mm x 3.2mm; ESR (Equivalent Series Resistance): 40R; Frequency Stability: 50ppm; Series Resistance: 60R; Accuracy: 20ppm; Case/Package: CSMD; Component Kind: Standard; Depth: 3.2mm; Frequency: 16MHz; Frequency Tolerance: 20ppm; Height: 0.051inch; Lead Free: Lead Free; Length: 5mm; Library Name: Altium Content Vault; Load Capacitance: 18pF; Max Operating Temperature: 70°C; Min Operating Temperature: -20°C; Mount: Surface Mount; Number of Pins: 2; Operating Frequency: 16 MHz; Package Quantity: 1000; Packaging: Tape and Reel; REACH SVHC: Yes; Resistor Type: Ceramic; Series: ABM3; Termination: SMD/SMT; Tolerance: 20ppm; Width: 3.2mm
